# Altium schematic sheet Main.SchDoc(Main)
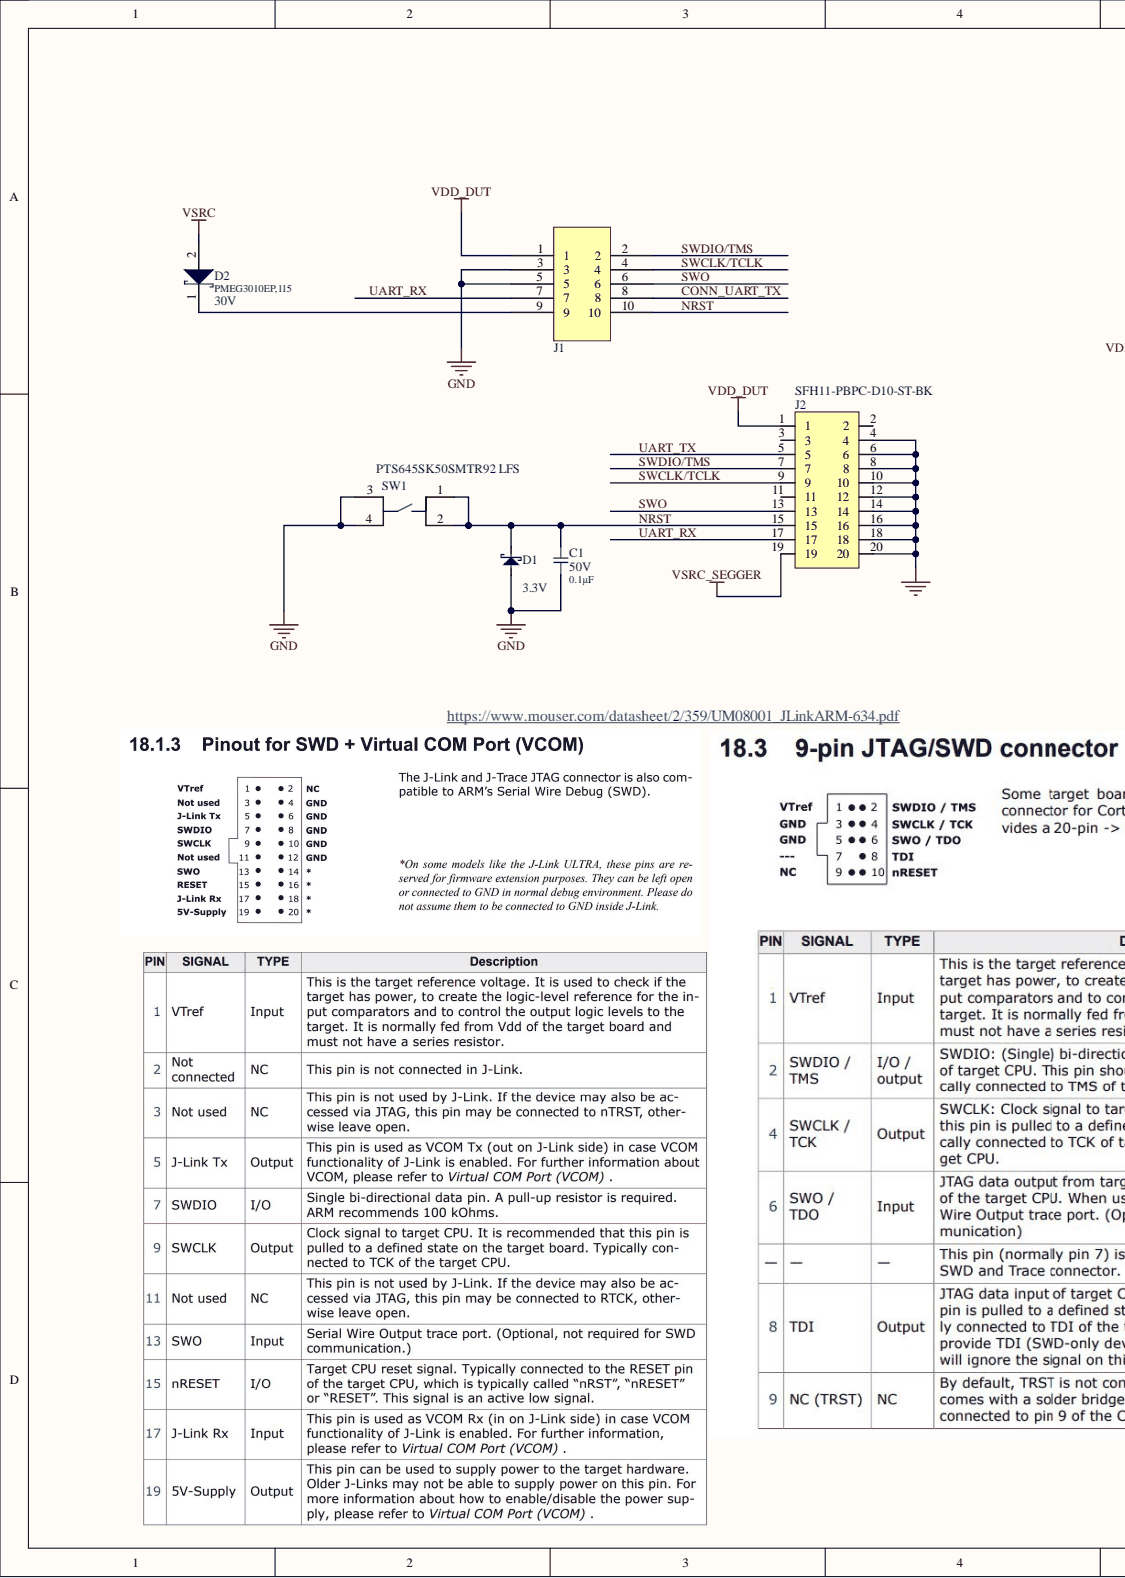
[diagram p0: Main.SchDoc, left]
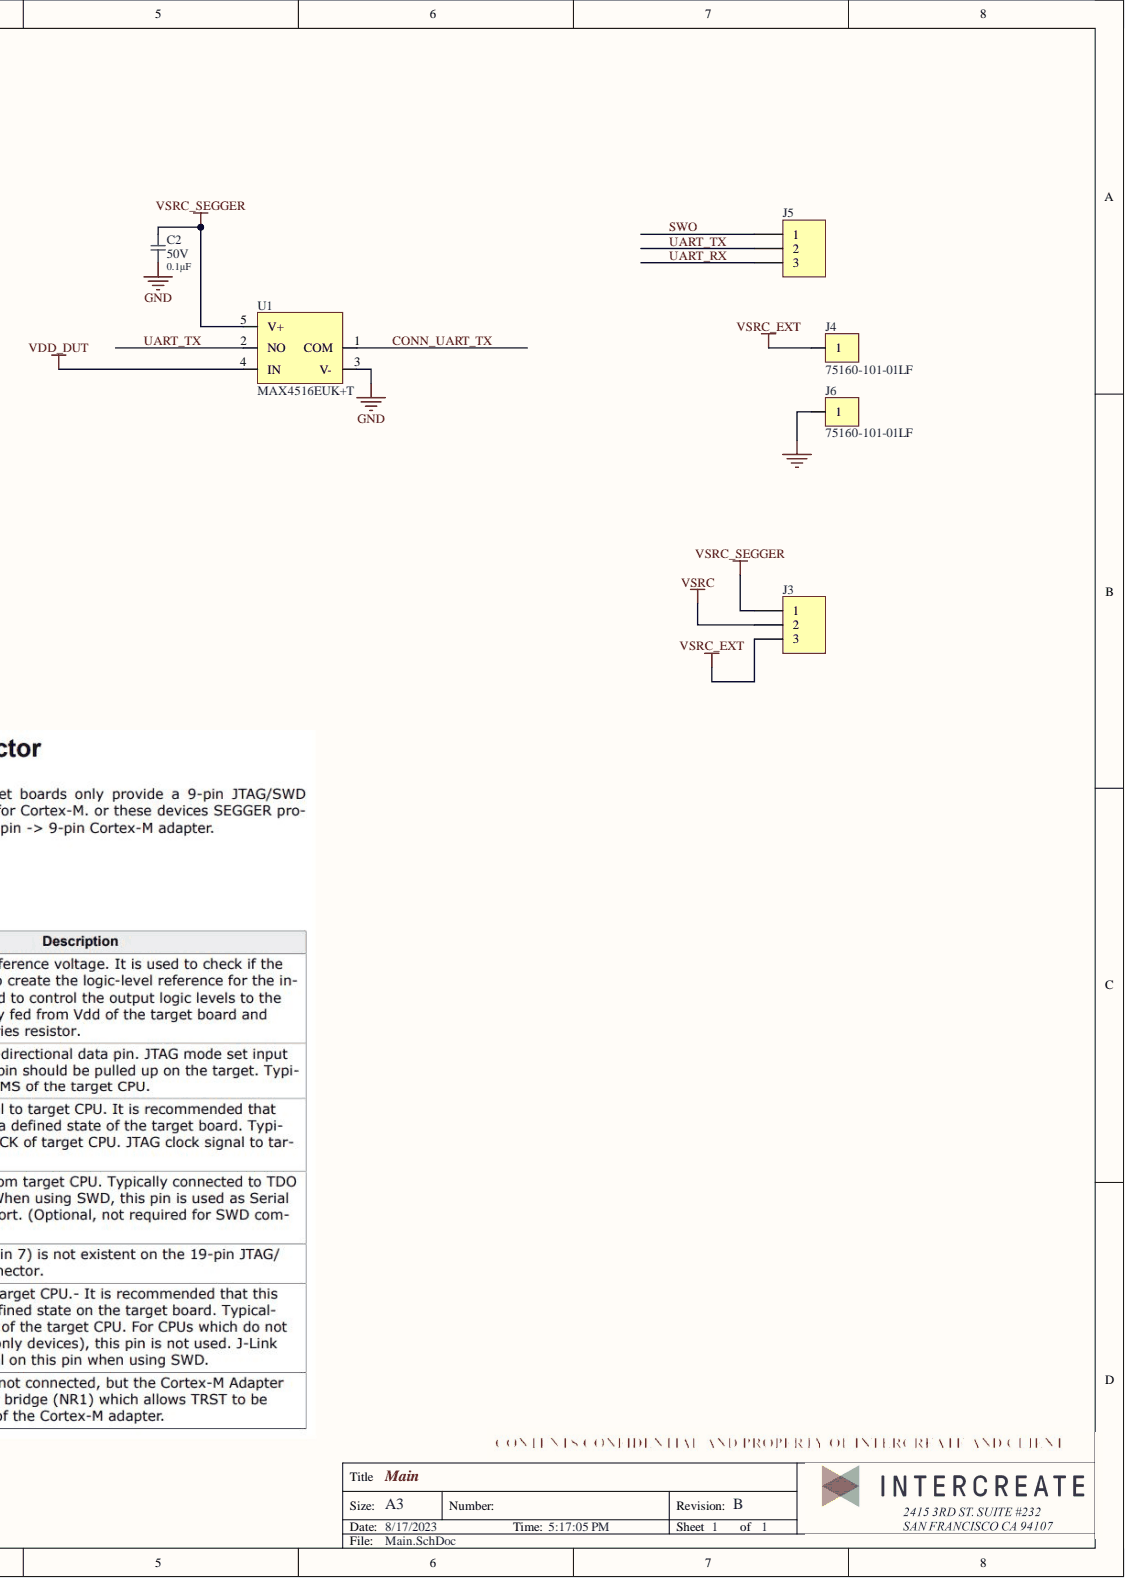
[diagram p1: Main.SchDoc, right]

## Parts
C1  value 0.1µF  Voltage Rating: 50V  [CAP-0402]  (hidden comment: GCM155R71H104KE02D)
C2  value 0.1µF  Voltage Rating: 50V  [CAP-0402]  (hidden comment: GCM155R71H104KE02D)
D1  Reverse Standoff Voltage: 3.3V  [DIODE-SOD923f]  (hidden comment: CPDQ3V3U-HF)
D2  PMEG3010EP,115  Reverse Voltage: 30V  [DIODE-SOD128]
J1  FTSH-105-01-L-DV-K  [HDR-V-2X5-M]  (value not drawn)
J2  SFH11-PBPC-D10-ST-BK  [HDR-V-2X10-F-2.54MM]
J3  61300311121  [HDR-V-1X3]  (value not drawn)
J4  75160-101-01LF  [HDR-V-1X1-M]
J5  61300311121  [HDR-V-1X3]  (value not drawn)
J6  75160-101-01LF  [HDR-V-1X1-M]
SW1  PTS645SK50SMTR92 LFS  [BTN-SPST-PTS645SK50SMTR92]
U1  MAX4516EUK+T  [IC-SOT-23-5]

## Nets
GND: C1.1 C2.1 D1.2 J1.3 J1.5 J2.4 J2.6 J2.8 J2.10 J2.12 J2.14 J2.16 J2.18 J2.20 J6.1 SW1.3 SW1.4 U1.3(V-)
VDD_DUT: J1.1 J2.1 U1.4(IN)
VSRC: D2.2 J3.2
VSRC_EXT: J3.3 J4.1
VSRC_SEGGER: C2.2 J2.19 J3.1 U1.5(V+)
CONN_UART_TX: J1.8 U1.1(COM)
NRST: C1.2 D1.1 J1.10 J2.15 SW1.1 SW1.2
SWCLK/TCLK: J1.4 J2.9
SWDIO/TMS: J1.2 J2.7
SWO: J1.6 J2.13 J5.1
UART_RX: J1.7 J2.17 J5.3
UART_TX: J2.5 J5.2 U1.2(NO)
NetD2_1: D2.1 J1.9

Not connected: J2 2 3 11

## Library data of the parts
C1 C2 = CAP-0.1uF-50V-0402: CAP CER 0.1UF 50V X7R 0402 10%; Manufacturer 1: Murata; Capacitance: 100nF; Case Code (Imperial): 0402; Case Code (Metric): 1005; Case/Package: 0402; Component Kind: Standard; ComponentLink1Description: http://www.mouser.com/ds/2/281/c03e-806517.pdf?src-supplier=Mouser; ComponentLink1URL: http://www.mouser.com/ds/2/281/c03e-806517.pdf?src-supplier=Mouser; ComponentLink2Description: http://www.farnell.com/datasheets/2079122.pdf?src-supplier=Farnell; ComponentLink2URL: http://www.farnell.com/datasheets/2079122.pdf?src-supplier=Farnell; ComponentLink3Description: http://www.farnell.com/datasheets/2079122.pdf?src-supplier=Newark; ComponentLink3URL: http://www.farnell.com/datasheets/2079122.pdf?src-supplier=Newark; ComponentLink4Description: http://www.farnell.com/datasheets/2079122.pdf?src-supplier=Element14; ComponentLink4URL: http://www.farnell.com/datasheets/2079122.pdf?src-supplier=Element14; ComponentLink5Description: http://search.murata.co.jp/Ceramy/image/img/A01X/partnumbering_e_02.pdf?src-supplier=Digi-Key; ComponentLink5URL: http://search.murata.co.jp/Ceramy/image/img/A01X/partnumbering_e_02.pdf?src-supplier=Digi-Key; Dielectric: X7R; Height: 0.5mm; Lead Free: Lead Free; Length: 0.039inch; Manufacturer Part Number 1: GCM155R71H104KE02D; Max Operating Temperature: 125°C; Min Operating Temperature: -55°C; Mount: Surface Mount; Package Quantity: 10000; Packaging: Tape and Reel; Pricing 1: 1=0.10000, 10=0.06100, 100=0.02730, 500=0.01952, 1000=0.01533, 2500=0.01394, 5000=0.01282 (USD); Ratings: AEC-Q200; REACH SVHC: No SVHC; RoHS: Yes; Stock 1: 254896; Supplier 1: Digi-Key; Supplier Part Number 1: 490-10672-1-ND; Termination: SMD/SMT; Thickness: 0.022inch; Tolerance: 10%; Voltage Rating (DC): 50V; Weight: 0.000212oz; Width: 0.5mm; Library Name: intercreate.IntLib
D1 = DIODE-TVS-3.3V-SOD923F: TVS DIODE 3.3V 10.4V SOD923F; Manufacturer 1: Comchip; Breakdown Voltage: 5V; Clamping Voltage: 14.1V; Diode Type: ZENER; Number of Channels: 1; Peak Pulse Current: 9.8A; Peak Pulse Power: 102W; Power Line Protection: No; Category: TVS Diodes; Component Kind: Standard; ComponentLink1Description: https://datasheet.ciiva.com/6578/cpdq3v3u-hf-6578247.pdf?src-supplier=Digi-Key; ComponentLink1URL: https://datasheet.ciiva.com/6578/cpdq3v3u-hf-6578247.pdf?src-supplier=Digi-Key; ComponentLink2Description: https://datasheet.ciiva.com/12545/cpdq3v3u-hf-216634-12545533.pdf?src-supplier=Mouser; ComponentLink2URL: https://datasheet.ciiva.com/12545/cpdq3v3u-hf-216634-12545533.pdf?src-supplier=Mouser; Manufacturer Part Number 1: CPDQ3V3U-HF; Max Operating Temperature: 150°C; Min Breakdown Voltage: 5V; Min Operating Temperature: -55°C; Mount: Surface Mount; Number of Unidirectional Channels: 1; Package Quantity: 8000; Packaging: Tape and Reel; Pricing 1: 1=0.35000, 10=0.29000, 100=0.15360, 500=0.10106, 1000=0.06872, 2000=0.06199 (USD); Resistor Type: Zener; RoHS: Yes; RoHS Compliant: Yes; Series: -; Stock 1: 9425; Supplier 1: Digi-Key; Supplier Part Number 1: 641-1477-1-ND; Termination: SMD/SMT; Library Name: intercreate.IntLib
D2 = DIODE-SCHOTTKY-30V-1A-SOD128: DIODE SCHOTTKY 30V 1A SOD128; Manufacturer 1: Nexperia USA Inc.; Capacitance @ Vr, F: 170pF @ 1V, 1MHz; Speed: Fast Recovery =< 500ns, > 200mA (Io); Base Part Number: PMEG3010; Category: Discrete Semiconductor Products; Component Kind: Standard; ComponentLink1Description: https://assets.nexperia.com/documents/data-sheet/PMEG3010EP.pdf; ComponentLink1URL: https://assets.nexperia.com/documents/data-sheet/PMEG3010EP.pdf; Current - Average Rectified (Io): 1A; Diode Type: Schottky; Library Name: diodes.SchLib; Manufacturer Part Number 1: PMEG3010EP,115; Mounting Type: Surface Mount; Operating Temperature - Junction: 150°C (Max); Package / Case: SOD-128; Packaging: Tape & Reel (TR); Part Status: Active; Pricing 1: 3000=0.11556, 6000=0.10856, 15000=0.10155, 30000=0.09338 (USD); RoHS: RoHS Compliant; Stock 1: 0; Supplier 1: Digi-Key; Supplier Device Package: CFP5; Supplier Part Number 1: 1727-5408-1-ND
J1 = HDR-V-2X5-M-JTAG: CONN HEADER 10 POS DUAL .05" SMD; Manufacturer: Samtec; Connector Type: Male Pin; Gender: Male; Max Current Rating: 1.75A; Number of Contacts: 10; Pitch: 1.27mm; Row Spacing: 0.05inch; Colour: Black; Component Kind: Standard; ComponentLink1Description: https://datasheet.ciiva.com/728/ftsh-1xx-xx-xxx-dv-xxx-mkt-728872.pdf; ComponentLink1URL: https://datasheet.ciiva.com/728/ftsh-1xx-xx-xxx-dv-xxx-mkt-728872.pdf; ComponentLink2Description: https://datasheet.ciiva.com/10245/ftsh-mt-10245272.pdf; ComponentLink2URL: https://datasheet.ciiva.com/10245/ftsh-mt-10245272.pdf; ComponentLink3Description: https://datasheet.ciiva.com/16657/ftsh-mt-16657372.pdf; ComponentLink3URL: https://datasheet.ciiva.com/16657/ftsh-mt-16657372.pdf; ComponentLink4Description: https://datasheet.ciiva.com/22703/ftsh-smt-22703900.pdf; ComponentLink4URL: https://datasheet.ciiva.com/22703/ftsh-smt-22703900.pdf; ComponentLink5Description: https://datasheet.ciiva.com/26936/getdatasheetpartid-175877-26936879.pdf; ComponentLink5URL: https://datasheet.ciiva.com/26936/getdatasheetpartid-175877-26936879.pdf; Contact Material: Bronze; Contact Mating Length: 0.12inch; Contact Plating: Nickel,Gold; Depth: 3.43mm; Housing Colour: Black; Housing Material: Polymer; Lead Free: Lead Free; Length: 6.35mm; Manufacturer 1: Samtec; Manufacturer Part Number 1: FTSH-105-01-L-DV-K; Max Operating Temperature: 125°C; Min Operating Temperature: -55°C; Mount: Surface Mount; MPN: FTSH-105-01-L-DV-K; Number of Positions: 10; Number of Rows: 2; Orientation: Straight; Package Quantity: 1; Packaging: Rail/Tube; Pricing 1: 1=3.13, 10=3, 100=2.5, 500=2.125, 1000=1.8125, 5000=1.625 (USD); Radiation Hardening: No; REACH SVHC: No SVHC; Stock 1: 7189; Supplier 1: Digi-Key; Supplier Part Number 1: SAM8799-ND; Termination: Solder; Library Name: intercreate.IntLib
J2 = HDR-V-2X10-F-2.54MM: 20 Position Header Connector 0.100" (2.54mm) Through Hole Gold; Manufacturer 1: Sullins; Number of Positions: 20; Pitch: 0.1inch; Category: Headers and Wire Housings; Color: Black; Component Kind: Standard; ComponentLink1Description: https://datasheet.ciiva.com/754/sfh11-pxxc-dxx-st-xx11160-c-754558.pdf?src-supplier=Digi-Key; ComponentLink1URL: https://datasheet.ciiva.com/754/sfh11-pxxc-dxx-st-xx11160-c-754558.pdf?src-supplier=Digi-Key; Connector Type: Header; Contact Plating: Gold; Contact Type: Female Socket; Lead Free: Lead Free; Manufacturer Part Number 1: SFH11-PBPC-D10-ST-BK; Mount: Through Hole; Number of Rows: 2; Package Quantity: 1; Packaging: Tray; Pricing 1: 1=1.15000, 10=0.96800, 80=0.86925, 440=0.71118, 1000=0.63216, 5000=0.57070 (USD); RoHS: Yes; RoHS Compliant: Yes; Row Spacing: 0.1inch; Series: SFH11; Stock 1: 51703; Supplier 1: Digi-Key; Supplier Part Number 1: S9197-ND; Termination: Solder; Library Name: intercreate.IntLib
J3 J5 = HDR-V-1X3-M: Header, 3-Pin, 0.1"; Manufacturer: Wurth Electronics; Connector Type: Male Pin; Gender: Male; Number of Contacts: 3; Pitch: 0.1inch; Colour: Black; Component Kind: Standard; ComponentLink1Description: http://www.farnell.com/cad/1737268.pdf; ComponentLink1URL: http://www.farnell.com/cad/1737268.pdf; ComponentLink2Description: http://katalog.we-online.de/em/datasheet/6130xx11121.pdf; ComponentLink2URL: http://katalog.we-online.de/em/datasheet/6130xx11121.pdf; Contact Material: Copper; Contact Mating Length: 0.236inch; Contact Plating: Gold; LatestRevisionDate: 17-Jul-2002; LatestRevisionNote: Re-released for DXP Platform.; Lead Free: Lead Free; Manufacturer 1: Wurth Electronics; Manufacturer Part Number 1: 61300311121; Mount: Through Hole; MPN: 61300311121; Number of Positions: 3; Number of Rows: 1; Packaging: Bulk; Pricing 1: 1=0.13, 10=0.106, 25=0.088, 50=0.074, 100=0.067, 1000=0.061 (USD); REACH SVHC: Unknown; Signal Integrity: Connector; Stock 1: 41782; Supplier 1: Digi-Key; Supplier Part Number 1: 732-5316-ND; Termination: Solder; Library Name: intercreate.IntLib; Publisher: Altium Limited
J4 J6 = HDR-V-1X1-M: BergStik®, Board to Board connector, Unshrouded vertical header, through hole, single Row, 1 position, 2.54mm pitch; Manufacturer 1: Amphenol ICC / FCI; Connector Type: Male Pin; Current Rating: 3A; Gender: Male; Insulation Resistance: 5GR; Number of Contacts: 1; Voltage Rating: 1.5kV; Category: Connectors; Color: Black; Component Kind: Standard; ComponentLink1Description: https://datasheet.ciiva.com/29149/75160-29149349.pdf?src-supplier=Digi-Key; ComponentLink1URL: https://datasheet.ciiva.com/29149/75160-29149349.pdf?src-supplier=Digi-Key; Contact Gender: Male; Contact Material: Bronze; Contact Mating Length: 0.225inch; Contact Plating: Gold,GXT; Contact Post Length: 2.54mm; Contact Type: Male Pin; Depth: 2.8mm; Housing Color: Black; Housing Material: Thermoplastic; Length: 2.54mm; Manufacturer Part Number 1: 75160-101-01LF; Mounting Technology: Through Hole; Number of Positions: 1; Number of Rows: 1; Orientation: Straight; Package Quantity: 1000; Packaging: Bulk; Pitch: 2.54mm; Pricing 1: 1=0.36000, 10=0.27000, 50=0.27000, 100=0.21400, 1000=0.16800, 10000=0.12900 (USD); Radiation Hardening: No; RoHS: Yes; Series: BERGSTIK®, MezzSelect™, Basics+; Stock 1: 4529; Supplier 1: Mouser; Supplier Part Number 1: 649-75160-101-01LF; Termination: Solder; Library Name: intercreate.IntLib
SW1 = BTN-SPST-PTS645SK50SMTR92: C & K COMPONENTS - PTS645SK50SMTR92LFS - SWITCH, SPST, 0.05A, 12VDC, SMD, 5MM; Manufacturer 1: ITT C&K; Actuator Length: 1.55mm; Actuator Material: Nylon,Polyamide,PA66; Actuator Type: Standard; Contact Resistance: 100mR; Current Rating: 50mA; Illumination Type: Non-Illuminated,Illuminated; Max Voltage Rating (DC): 12V; Mechanical Life: 100000Cycles; Operating Force: 260gf; Switch Function: Off-Mom; Case/Package: SMD/SMT; Category: Tactile Switches; Color: Red; Component Kind: Standard; ComponentLink1Description: https://datasheet.ciiva.com/29903/getdatasheetpartid-741134-29903854.pdf?src-supplier=Verical; ComponentLink1URL: https://datasheet.ciiva.com/29903/getdatasheetpartid-741134-29903854.pdf?src-supplier=Verical; ComponentLink2Description: https://datasheet.ciiva.com/14920/pts645-14920581.pdf?src-supplier=Digi-Key; ComponentLink2URL: https://datasheet.ciiva.com/14920/pts645-14920581.pdf?src-supplier=Digi-Key; ComponentLink3Description: https://datasheet.ciiva.com/22637/1707731-22637358.pdf?src-supplier=Element14; ComponentLink3URL: https://datasheet.ciiva.com/22637/1707731-22637358.pdf?src-supplier=Element14; Contact Material: Bronze; Contact Plating: Silver; Depth: 9mm; Lead Free: Lead Free; Length: 6mm; Manufacturer Part Number 1: PTS645SK50SMTR92LFS; Max Current Rating: 50mA; Max Operating Temperature: 60°C; Min Operating Temperature: -20°C; Mount: Surface Mount; Orientation: Straight; Package Quantity: 1; Packaging: Tape and Reel; Pricing 1: 1=0.15000, 10=0.14900, 25=0.14120, 100=0.12840, 250=0.12068, 500=0.11042 (USD); REACH SVHC: No SVHC; RoHS: Yes; RoHS Compliant: Yes; Series: PTS645; Stock 1: 52601; Supplier 1: Digi-Key; Supplier Part Number 1: CKN9087CT-ND; Termination: Gull Wing; Throw Configuration: SPST; Voltage Rating (DC): 12V; Library Name: intercreate.IntLib
U1 = IC-SW-MAX4516: MAX4516 Series SPST-NO 6V 20 Ohm SMT Analog Switch - SOT-23; Manufacturer 1: Maxim; Dual Supply Voltage: 5V; Max Power Dissipation: 571mW; Max Supply Current: 125uA; Min Dual Supply Voltage: 1V; Number of Channels: 1; On-State Resistance: 20R; Operating Supply Current: 40uA; Supply Type: Dual; Throw Configuration: SPST; Turn-Off Delay Time: 75ns; Turn-On Delay Time: 100ns; Case/Package: SOT-23-5; Category: Decoders and Multiplexers; Component Kind: Standard; ComponentLink1Description: https://datasheet.ciiva.com/1673/max4516-max4517-1673360.pdf?src-supplier=Digi-Key; ComponentLink1URL: https://datasheet.ciiva.com/1673/max4516-max4517-1673360.pdf?src-supplier=Digi-Key; ComponentLink2Description: https://datasheet.ciiva.com/1673/max4516-max4517-1673359.pdf?src-supplier=Digi-Key; ComponentLink2URL: https://datasheet.ciiva.com/1673/max4516-max4517-1673359.pdf?src-supplier=Digi-Key; ComponentLink3Description: https://datasheet.ciiva.com/1673/max4516-max4517-1673343.pdf?src-supplier=Digi-Key; ComponentLink3URL: https://datasheet.ciiva.com/1673/max4516-max4517-1673343.pdf?src-supplier=Digi-Key; ComponentLink4Description: https://datasheet.ciiva.com/2979/max4516-max4517-2979725.pdf?src-supplier=Avnet; ComponentLink4URL: https://datasheet.ciiva.com/2979/max4516-max4517-2979725.pdf?src-supplier=Avnet; Manufacturer Part Number 1: MAX4516EUK+T; Max Dual Supply Voltage: 6V; Max Operating Temperature: 85°C; Min Operating Temperature: -40°C; Mounting Technology: Surface Mount; Operating Supply Voltage: 5V; Package Quantity: 1; Packaging: Tape and Reel; Pins: 5; Pricing 1: 1=2.88000, 10=2.60000, 25=2.45000, 50=2.27000, 100=2.09000, 250=1.96000, 500=1.71000, 1000=1.47000 (USD); Radiation Hardening: No; RoHS: Yes; RoHS Compliant: Yes; Series: -; Stock 1: 1593; Supplier 1: Newark; Supplier Part Number 1: 73Y4225; Weight: 0.001058oz; Library Name: intercreate.IntLib
